# Altium schematic sheet MRX80SQUARE.SchDoc
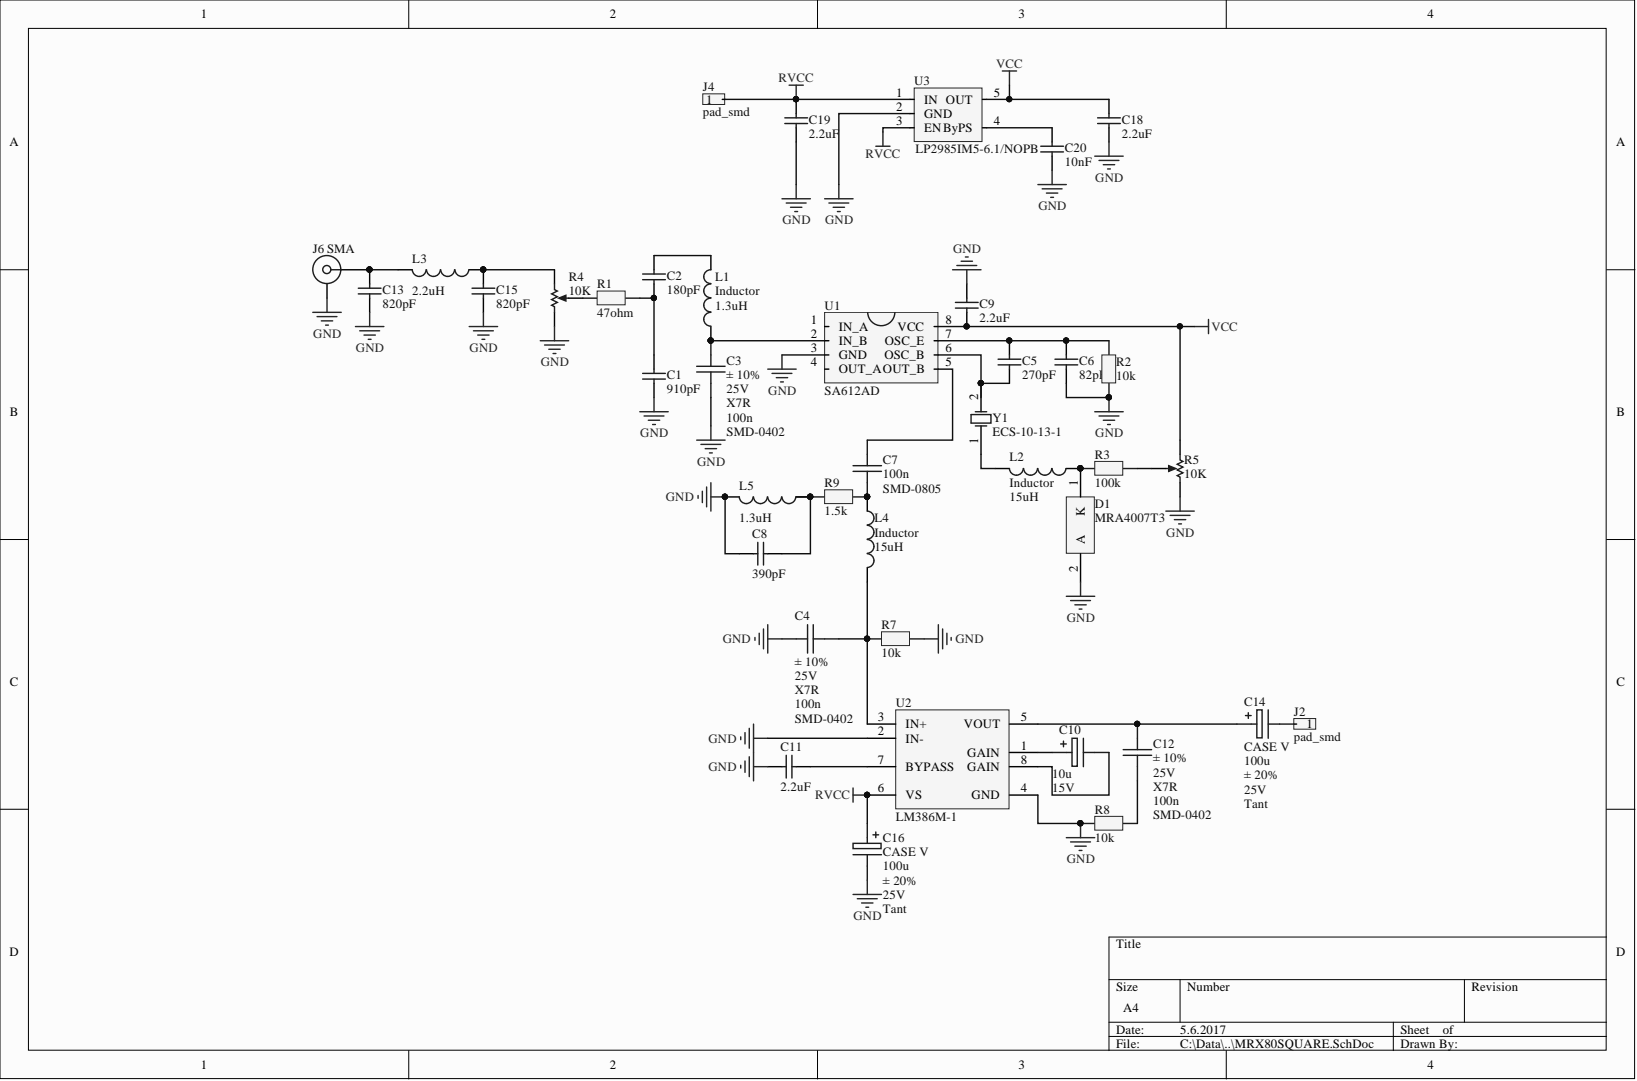
[diagram p0: MRX80SQUARE.SchDoc]

## Parts
C1  value 910pF  [0402]  (hidden comment: Cap Semi)
C2  value 180pF  [0402]  (hidden comment: Cap Semi)
C3  value 100n  Tolerance: ± 10%  Type: X7R  Voltage: 25V  [CAPC1005X04N_0402]  (hidden comment: Capacitor)
C4  value 100n  Tolerance: ± 10%  Voltage: 25V  [CAPC1005X04N_0402]  (hidden comment: Capacitor)
C5  value 270pF  [0402]  (hidden comment: Cap Semi)
C6  value 82pF  [0402]  (hidden comment: Cap Semi)
C7  value 100n  PackageReference: SMD-0805  [CAPC2012X06N_0806]  (hidden comment: Capacitor)
C8  value 390pF  [0402]  (hidden comment: Cap Semi)
C9  value 2.2uF  [0402]  (hidden comment: Cap Semi)
C10  value 10u  Voltage: 15V  [CAP_CASE_B_1210_m3225]  (hidden comment: Capacitor)
C11  value 2.2uF  [0402]  (hidden comment: Cap Semi)
C12  value 100n  Tolerance: ± 10%  Type: X7R  Voltage: 25V  [CAPC1005X04N_0402]  (hidden comment: Capacitor)
C13  value 820pF  [0402]  (hidden comment: Cap Semi)
C14  value 100u  PackageDescription: CASE V  [CAP_CASE_V_2924_m7361-38]  (hidden comment: Capacitor)
C15  value 820pF  [0402]  (hidden comment: Cap Semi)
C16  value 100u  Tolerance: ± 20%  Type: Tant  Voltage: 25V  [CAP_CASE_V_2924_m7361-38]  (hidden comment: Capacitor)
C18  value 2.2uF  [0402]  (hidden comment: Cap Semi)
C19  value 2.2uF  [0402]  (hidden comment: Cap Semi)
C20  value 10nF  [0402]  (hidden comment: Cap Semi)
D1  MRA4007T3  [ONSC-SMA-2-403D-02_V]
J2  pad_smd  [pad_smd_bodge]
J4  pad_smd  [pad_smd_bodge]
J6  SMA  [ROS-32K145-400L5_V]
L1  Inductor  value 1.3uH  [0402-A]
L2  Inductor  value 15uH  [0402-A]
L3  Inductor  value 2.2uH  [0603]
L4  Inductor  value 15uH  [0402-A]
L5  Inductor  value 1.3uH  [0402-A]
R1  value 47ohm  [RESC1005X04N_0402]  (hidden comment: Resistor)
R2  value 10k  [RESC1005X04N_0402]  (hidden comment: Resistor)
R3  value 100k  [RESC1005X04N_0402]  (hidden comment: Resistor)
R4  value 10K  [VR5]  (hidden comment: RPot)
R5  value 10K  [VR5]  (hidden comment: RPot)
R7  value 10k  [RESC1005X04N_0402]  (hidden comment: Resistor)
R8  value 10k  [RESC1005X04N_0402]  (hidden comment: Resistor)
R9  value 1.5k  [RESC1608X06N_0603]  (hidden comment: Resistor)
U1  SA612AD  [SO8_SOIC8]
U2  LM386M-1  [M08A_N]
U3  LP2985IM5-6.1/NOPB  [SOT23-5]
Y1  ECS-10-13-1  [HC-49U]

## Nets
GND: C1.1 C3.1 C4.2 C6.1 C8.2 C9.2 C11.2 C13.1 C15.1 C16.2 C18.1 C19.1 C20.1 D1.2 J6.MP L5.2 R2.1 R4.1 R5.2 R7.1 R8.1 U1.3(GND) U2.2(IN-) U2.4(GND) U3.2(GND)
RVCC: C16.1 C19.2 J4.1 U2.6(VS) U3.1(IN) U3.3(EN)
VCC: C9.1 C18.2 R5.1 U1.8(VCC) U3.5(OUT)
NetC1_2: C1.2 C2.1 R1.2
NetC2_2: C2.2 L1.2
NetC3_2: C3.2 L1.1 U1.2(IN_B)
NetC4_1: C4.1 L4.2 R7.2 U2.3(IN+)
NetC5_1: C5.1 U1.6(OSC_B) Y1.2
NetC5_2: C5.2 C6.2 R2.2 U1.7(OSC_E)
NetC7_1: C7.1 L4.1 R9.2
NetC7_2: C7.2 U1.5(OUT_B)
NetC8_1: C8.1 L5.1 R9.1
NetC10_1: C10.1 U2.1(GAIN)
NetC10_2: C10.2 U2.8(GAIN)
NetC11_1: C11.1 U2.7(BYPASS)
NetC12_1: C12.1 R8.2
NetC12_2: C12.2 C14.1 U2.5(VOUT)
NetC13_2: C13.2 J6.1 L3.2
NetC14_2: C14.2 J2.1
NetC15_2: C15.2 L3.1 R4.2
NetC20_2: C20.2 U3.4(ByPS)
NetD1_1: D1.1 L2.1 R3.1
NetL2_2: L2.2 Y1.1
NetR1_1: R1.1 R4.3
NetR3_2: R3.2 R5.3

Not connected: U1 1(IN_A) 4(OUT_A)

## Library data of the parts
C1 C2 C5 C6 C8 C9 C11 C13 C15 C18-C20 = Cap Semi: Capacitor (Semiconductor SIM Model); Component Kind: Standard; LatestRevisionDate: 29-May-2009; LatestRevisionNote: IPC-7351 Footprint Added.; Library Name: Miscellaneous Devices.IntLib; PackageDescription: Chip Capacitor; PackageReference: C1206; Published: 8-Jun-2000; Signal Integrity: Cap Semi; Simulation: CAP; Publisher: Altium Limited
C3 C12 = Capacitor_100n_0402_Bulk: Class 1 SMD Capacitor; Manufacturer: MULTICOMP; ManufacturerRef: MC0402B104K250CT; OrderCode: 2310482; PowerRating: N.A.; Seller: Farnell; TemperatureCoeff: ± 15%; Component Kind: Standard; PackageDescription: SMD; PackageReference: SMD-0402; PriceEuro: 0.0055; Published: 9.5.2014; Library Name: AQA_20007_4_Flange.IntLib; Publisher: atr
C4 = Capacitor_100n_0402_Bulk: Class 1 SMD Capacitor; Manufacturer: MULTICOMP; ManufacturerRef: MC0402B104K250CT; OrderCode: 2310482; PowerRating: N.A.; Seller: Farnell; TemperatureCoeff: ± 15%; Type: X7R; Value: 100n; Component Kind: Standard; PackageDescription: SMD; PackageReference: SMD-0402; PriceEuro: 0.0055; Published: 9.5.2014; Library Name: AQA_20007_4_Flange.IntLib; Publisher: atr
C7 = Capacitor_47n_0805_C0G: Class 1 SMD Capacitor; Manufacturer: Kemet; ManufacturerRef: C0805C473J3GACTU; OrderCode: 1535570RL; PowerRating: N.A.; Seller: Farnell; TemperatureCoeff: 0ppm/°C ± 30ppm/°C; Tolerance: ± 5%; Type: C0G / NP0; Voltage: 25V; Component Kind: Standard; PackageDescription: SMD; PriceEuro: 0.28; Published: 9.5.2014; Library Name: AQA_atr_2013.IntLib; Publisher: atr
C10 = Capacitor_100u_Tant_SMD_CASE_B_m3525: Tant SMD Capacitor; Manufacturer: AVX; ManufacturerRef: TPSB107M010R0400; ESR: 0.4Ohm; OrderCode: 1658510RL; PowerRating: N.A.; Seller: Farnell; TemperatureRange: -55°C to +125°C; Tolerance: ± 20%; Component Kind: Standard; PackageDescription: CASE B; PackageReference: SMD-1210 [3225 Metric]; PriceEuro: 0.89; Published: 15.5.2014; TemperatureCoeff: -; Type: Tant; Library Name: AQA_atr_2013.IntLib; Publisher: atr
C14 = Capacitor_100u_Tant_SMD_CASE_V_m7361: Tant SMD Capacitor; Manufacturer: AVX; ManufacturerRef: TPSV107K025R0100; ESR: 0.1Ohm; OrderCode: 1659002; PowerRating: N.A.; Seller: Farnell; TemperatureRange: -55°C to +125°C; Tolerance: ± 20%; Voltage: 25V; Component Kind: Standard; LatestRevisionDate: 3.9.2014; LatestRevisionNote: 3.9.2014; PackageReference: SMD-2924 [7361 Metric]; PriceEuro: 4.94; Published: 3.9.2014; TemperatureCoeff: -; Type: Tant; Library Name: AQA_20007_4_Flange.IntLib; Publisher: atr
C16 = Capacitor_100u_Tant_SMD_CASE_V_m7361: Tant SMD Capacitor; Manufacturer: AVX; ManufacturerRef: TPSV107K025R0100; ESR: 0.1Ohm; OrderCode: 1659002; PowerRating: N.A.; Seller: Farnell; TemperatureRange: -55°C to +125°C; Component Kind: Standard; LatestRevisionDate: 3.9.2014; LatestRevisionNote: 3.9.2014; PackageDescription: CASE V; PackageReference: SMD-2924 [7361 Metric]; PriceEuro: 4.94; Published: 3.9.2014; TemperatureCoeff: -; Library Name: AQA_20007_4_Flange.IntLib; Publisher: atr
D1 = MRA4007T3: Surface Mount Standard Recovery Power Rectifier, 2-Pin SMA, Tape and Reel; Manufacturer: On Semiconductor; IFSM Max (A): 30; IO(rec) Max (A): 1; VRRM Max (V): 1000; Component Kind: Standard; ComponentLink1Description: Manufacturer URL; ComponentLink1URL: http://www.onsemi.com/; ComponentLink2Description: Datasheet; ComponentLink2URL: http://www.onsemi.com/pub_link/Collateral/MRA4003T3-D.PDF; ComponentLink3Description: Package Specification; ComponentLink3URL: http://www.onsemi.com/pub_link/Collateral/403D-02.PDF; DatasheetVersion: Rev. 9; Library Name: ON Semi Rectifiers.IntLib; Mounting Technology: Surface Mount; PackageDescription: 2-Pin Small Molded Package, J-Bend Lead, Body 2.605 x 4.315 mm; PackageReference: SMA-2-403D-02; PackageVersion: Rev. G, 07/2012; Packing: Tape and Reel; PartNumber: MRA4007T3; RoHS: FALSE; Supplier 1: Digi-Key; Supplier 2: Digi-Key; Supplier 3: Digi-Key; Supplier Part Number 1: MRA4007T3OSTR-ND; Supplier Part Number 2: MRA4007T3OSCT-ND; Supplier Part Number 3: MRA4007T3OSDKR-ND; Type: Standard Recovery
J2 J4 = pad_smd_1mm*2mm: 1mm * 2mm smd pad; Component Kind: Standard; Library Name: AQA_Ismolib.IntLib
J6 = 32K145-400L5: SMA Right Angle Jack, Edge Mount, Up to 18 GHz, -55 to 155 degC; Desgin Note 2: For more information about DRC setting, please look at following webpage, http://techdocs.altium.com/display/SCRT/Design+Rule+for+Edge-Mount+Connectors; Design Note 1: Footprint in library is a generic example - only application specific footprint delivers High Performance; Component Kind: Standard; ComponentLink1Description: Manufacturer URL; ComponentLink1URL: http://www.rosenberger.de; ComponentLink2Description: Datasheet; ComponentLink2URL: http://rosenberger.de/ok/images/documents/db/32K145-400L5.pdf; ComponentLink3Description: Package Specification; ComponentLink3URL: http://rosenberger.de/ok/images/documents/db/32K145-400L5.pdf; ComponentLink4Description: Series Overview / Design Note; ComponentLink4URL: http://rosenberger.de/ok/images/documents/spez/32.pdf; ComponentLink5Description: Webshop / RFQ; ComponentLink5URL: http://www.rosenberger.com/en/contact/sales/; DatasheetVersion: b00; Mounting Technology: Surface Mount; PackageDescription: SMA Right Angle Jack, Edge Mount, 14.25 x 9.5 x 4.46 mm; PackageReference: 32K145-400L5; PackageVersion: b00; PartNumber: 32K145-400L5; Library Name: Rosenberger SMA.IntLib
L1-L4 = Inductor: Code_IEC: 1005; Code_JEITA: 0402; Component Kind: Standard; Description: Inductor; LatestRevisionDate: 29-May-2009; LatestRevisionNote: IPC-7351 Footprint Added.; Library Name: Miscellaneous Devices.IntLib; PackageDescription: Chip Inductor; PackageReference: 0402-A; Published: 8-Jun-2000; Signal Integrity: Inductor; Simulation: INDUCTOR; Publisher: Altium Limited
L5 = Inductor: Code_IEC: 1005; Value: 1.3uH; Code_JEITA: 0402; Component Kind: Standard; Description: Inductor; LatestRevisionDate: 29-May-2009; LatestRevisionNote: IPC-7351 Footprint Added.; Library Name: Miscellaneous Devices.IntLib; PackageDescription: Chip Inductor; PackageReference: 0402-A; Published: 8-Jun-2000; Signal Integrity: Inductor; Simulation: INDUCTOR; Publisher: Altium Limited
R1-R3 R7-R9 = Resistor_SMD_Generic: Generic SMD Resistor; Manufacturer: SUSUMU; ManufacturerRef: RR0816P-104-B-T5; OrderCode: 1653254RL; PowerRating: 63mW; Seller: Farnell; TemperatureCoeff: ± 25ppm/°C; Tolerance: ± 0.1%; Voltage: 75V; Component Kind: Standard; PackageDescription: SMD; PackageReference: SMD-0603; PriceEuro: 0.085; Type: Thin Film; Library Name: AQA_atr_2013.IntLib; Publisher: atr
R4 R5 = RPot: Potentiometer; Set Position: 0.5; Sim Note: Enter the Resistance value and the set point into parameter fields.; Component Kind: Standard; LatestRevisionDate: 17-Jul-2002; LatestRevisionNote: Re-released for DXP Platform.; Library Name: Miscellaneous Devices.IntLib; PackageDescription: Variable Resistor, Thru-Hole; 3 Leads; PackageReference: VR5; Published: 8-Jun-2000; Simulation: POT; Publisher: Altium Limited
U1 = SA612AD: Manufacturer 1: NXP Semiconductors; Manufacturer Part Number 1: SA612AD/01,118; Input Frequency: 500MHz; Power Gain: 17dB; Component Kind: Standard; ComponentLink1Description: https://datasheet.ciiva.com/27052/1460068-27052253.pdf; ComponentLink1URL: https://datasheet.ciiva.com/27052/1460068-27052253.pdf; ComponentLink2Description: https://datasheet.ciiva.com/15100/getdatasheetpartid-117259-15100947.pdf; ComponentLink2URL: https://datasheet.ciiva.com/15100/getdatasheetpartid-117259-15100947.pdf; ComponentLink3Description: https://datasheet.ciiva.com/3271/sa612a-3271368.pdf; ComponentLink3URL: https://datasheet.ciiva.com/3271/sa612a-3271368.pdf; Description: SA612AD; Operating Temperature (Max): 85C; Operating Temperature (Min): -40C; Operating Temperature Classification: Industrial; Package Type: SO; Packaging: Tape and Reel; Pricing 1: 1=2.34, 10=1.92, 50=1.92, 100=1.54, 1000=1.11, 10000=0.998 (USD); Stock 1: 1993; Supplier 1: Mouser; Supplier Part Number 1: SA612AD; Library Name: ftg_lib.IntLib
U2 = LM386M-1: Low Voltage Audio Power Amplifier, 8-pin Narrow SOIC; Manufacturer: National Semiconductor; CompType: Audio IC; High-Reliability: Y; PackageTopMarking: NSZXTT*LM386*M-1; PowerWise: N; Code_IPC: SOIC127P600-8; Code_JEDEC: MS-012-AA; Component Kind: Standard; ComponentLink1Description: Manufacturer URL; ComponentLink1URL: http://www.national.com/; ComponentLink2Description: LM386 Datasheet; ComponentLink2URL: http://www.national.com/ds/LM/LM386.pdf; ComponentLink3Description: LM386 Web Page; ComponentLink3URL: http://www.national.com/pf/LM/LM386.html; ComponentLink4Description: M08A Package Page; ComponentLink4URL: http://www.national.com/packaging/folders/m08a.html; DatasheetVersion: Aug-2000; Library Name: National Semiconductor Audio.IntLib; PackageDescription: SOIC Narrow, 4.9 x 3.9 x 1.45mm, 8 Lead, 1.27mm Pitch; PackageReference: M08A; PackageVersion: revM, May-2009; PartNumber: LM386M-1; PinCount: 8; RoHS: N
U3 = LP2985IM5-6.1/NOPB: 6.1V 150mA LDO; Manufacturer 1: Texas Instruments; Accuracy: ±3 %; Line Regulation: 0.014 %/V; Maximum Input Voltage: 16 V; Maximum Output Current: 0.15 A; Minimum Input Voltage: 2.5 V; Number of Outputs: 1; Output Type: Fixed; Polarity: Positive; Rad Hard: No; Typical Dropout Voltage @ Current: 0.0001@0mA|0.007@1mA|0.04@10mA|0.12@50mA|0.28@150mA V; Category: Linear Regulator; Component Kind: Standard; ComponentLink1Description: https://datasheet.ciiva.com/6592/lp2985-n-6592025.pdf; ComponentLink1URL: https://datasheet.ciiva.com/6592/lp2985-n-6592025.pdf; ComponentLink2Description: https://datasheet.ciiva.com/26168/lp2985-n-26168191.pdf; ComponentLink2URL: https://datasheet.ciiva.com/26168/lp2985-n-26168191.pdf; ComponentLink3Description: https://datasheet.ciiva.com/6592/lp2985-n-6592022.pdf; ComponentLink3URL: https://datasheet.ciiva.com/6592/lp2985-n-6592022.pdf; ComponentLink4Description: https://datasheet.ciiva.com/13028/lp2985-n-13028680.pdf; ComponentLink4URL: https://datasheet.ciiva.com/13028/lp2985-n-13028680.pdf; Manufacturer Part Number 1: LP2985IM5-6.1/NOPB; Mounting: Surface Mount; Operating Temperature: -40 to 125 °C; Output Voltage: 6.1 V; Package: 5SOT-23; Packaging: Tape & Reel; Pricing 1: 1=0.76, 10=0.632, 50=0.632, 100=0.408, 1000=0.326, 10000=0.265 (USD); Stock 1: 539; Supplier 1: Mouser; Supplier Part Number 1: 926-LP2985IM561NOPB; Type: Linear; Library Name: ftg_lib.IntLib
Y1 = ECS-10-13-1: Crystal Oscillator; Component Kind: Standard; ComponentLink1Description: Manufacturer Link; ComponentLink1URL: http://www.ecsxtal.com/; ComponentLink2Description: Datasheet; ComponentLink2URL: http://www.ecsxtal.com/pdf2/HC49U.PDF; DatasheetDocument: 18-Apr-2000; Library Name: ECS Crystal Oscillator.IntLib; PackageReference: HC-49U; Published: 17-Jul-2002; Simulation: XTAL; Publisher: Altium Limited
